# Altium schematic sheet MCS_diag_USB.SchDoc(MCS_diag_USB)
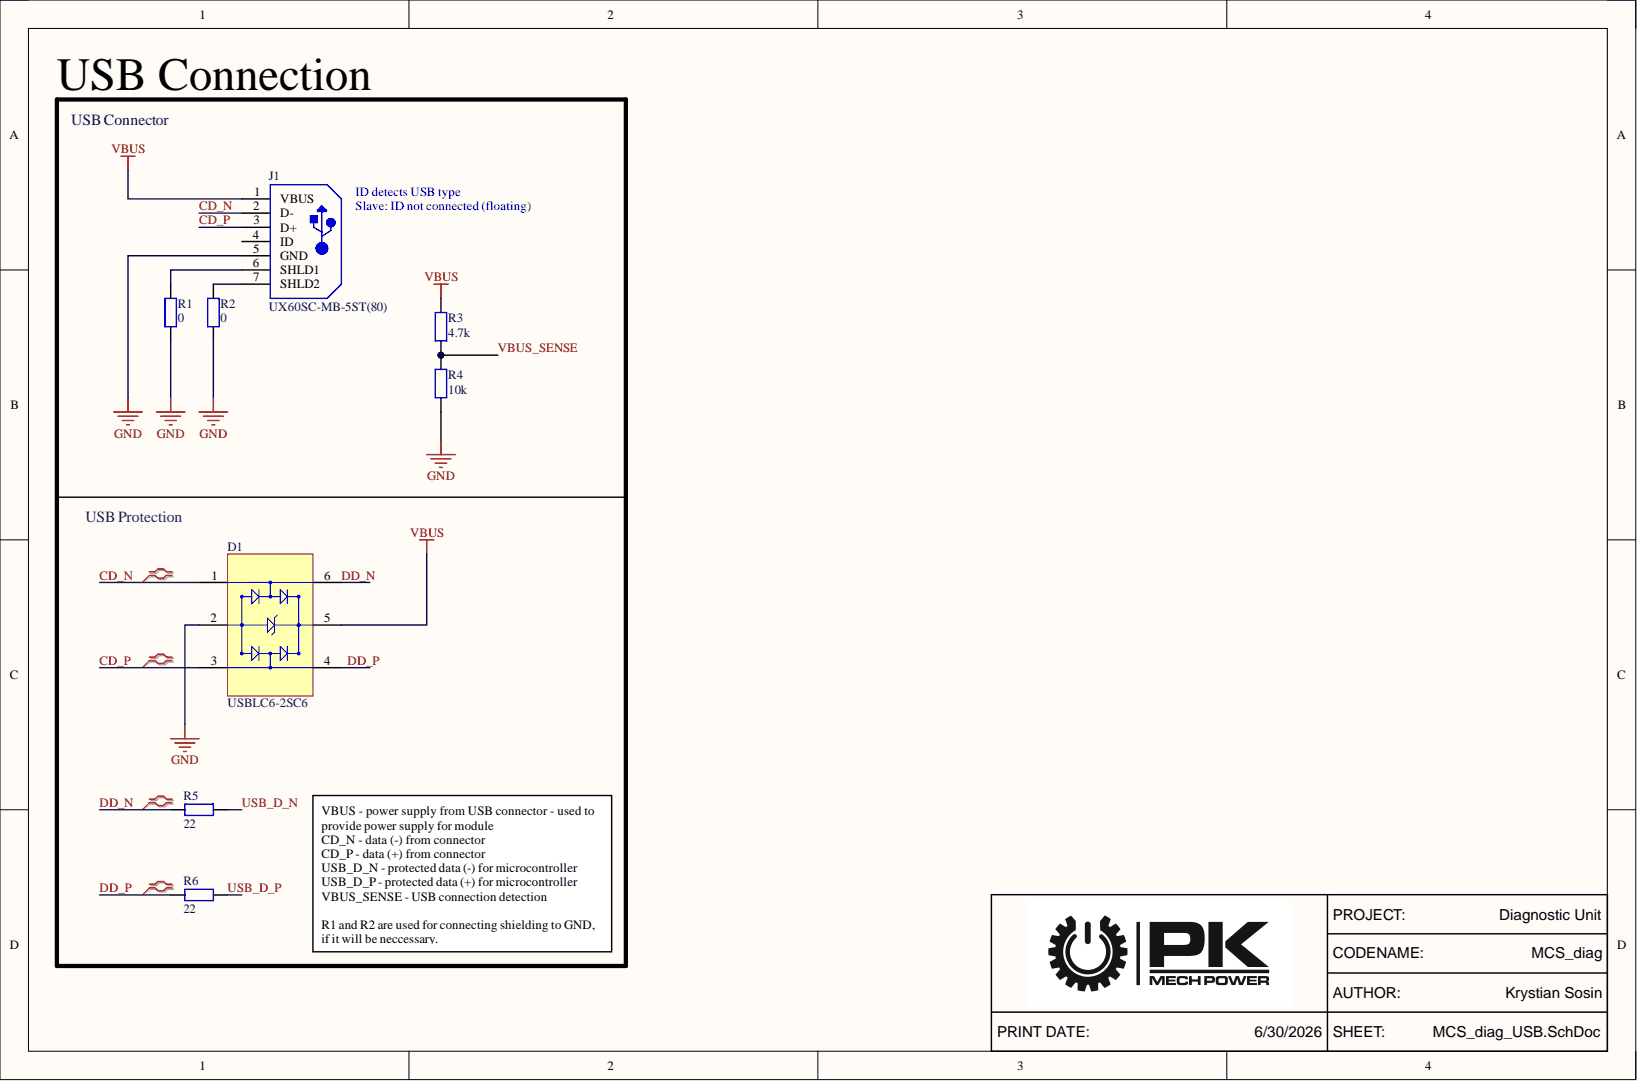
[diagram p0: MCS_diag_USB.SchDoc]

## Parts
D1  USBLC6-2SC6
J1  UX60SC-MB-5ST(80)
R1  value 0  (hidden comment: Resistor 0603)
R2  value 0  (hidden comment: Resistor 0603)
R3  value 4.7k  (hidden comment: Resistor 0603)
R4  value 10k  (hidden comment: Resistor 0603)
R5  value 22  (hidden comment: Resistor 0603)
R6  value 22  (hidden comment: Resistor 0603)

## Nets
GND: D1.2 J1.5(GND) R1.1 R2.1 R4.1
VBUS: D1.5 J1.1(VBUS) R3.2
CD_N: D1.1 J1.2(D-)
CD_P: D1.3 J1.3(D+)
DD_N: D1.6 R5.1
DD_P: D1.4 R6.1
USB_D_N: R5.2
USB_D_P: R6.2
VBUS_SENSE: R3.1 R4.2
NetJ1_6: J1.6(SHLD1) R1.2
NetJ1_7: J1.7(SHLD2) R2.2

Not connected: J1 4(ID)

## Library data of the parts
D1 = CMP-004-00011-2: USBLC6 Series 2 Line 6 V Uni / Bi-Directional ESD Protection - SOT-23-6; Component Kind: Standard; Library Name: EMEA- PK MechPower-  Cracow University of Technology
J1 = CMP-00006-2: Conn Mini-USB RCP 5 POS 0.8mm Solder RA Thru-Hole 5 Terminal 1 Port Embossed T/R; Component Kind: Standard; Library Name: EMEA- PK MechPower-  Cracow University of Technology
R1-R6 = CMP-009-00010-5: SMD 0603 resistor; Component Kind: Standard; Library Name: EMEA- PK MechPower-  Cracow University of Technology
